# Altium schematic sheet [07]-MCU.SchDoc
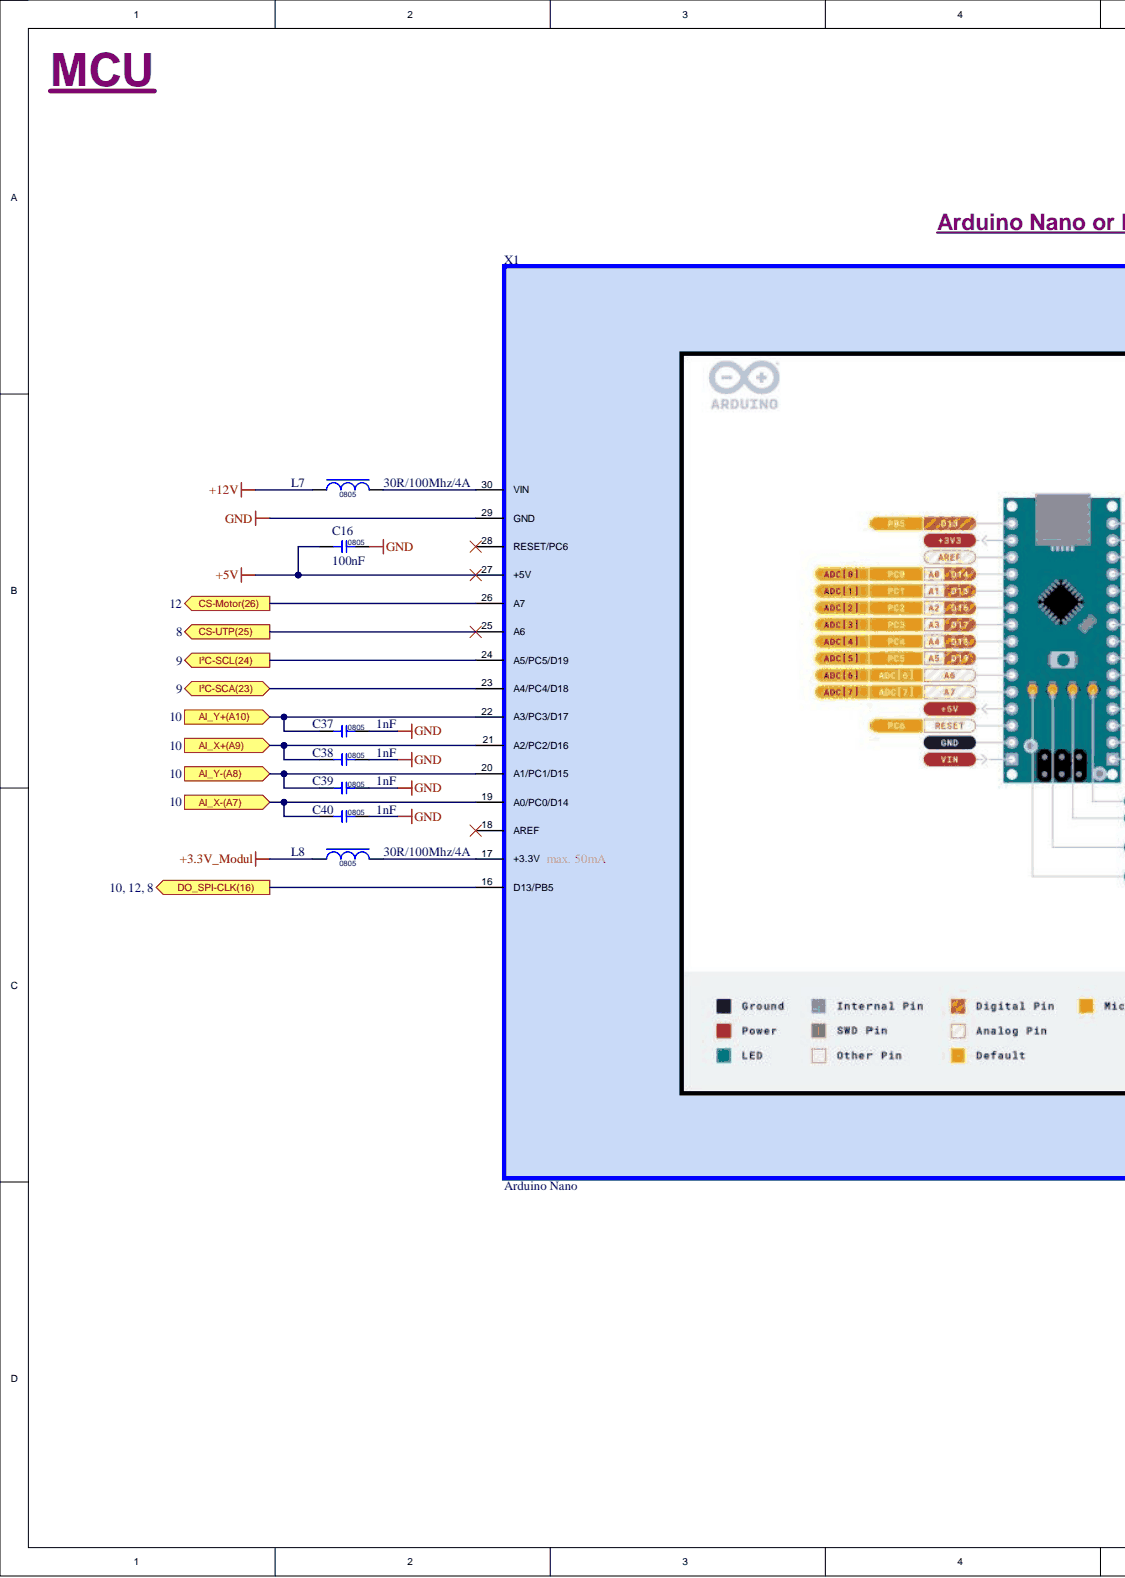
[diagram p0: [07]-MCU.SchDoc, left]
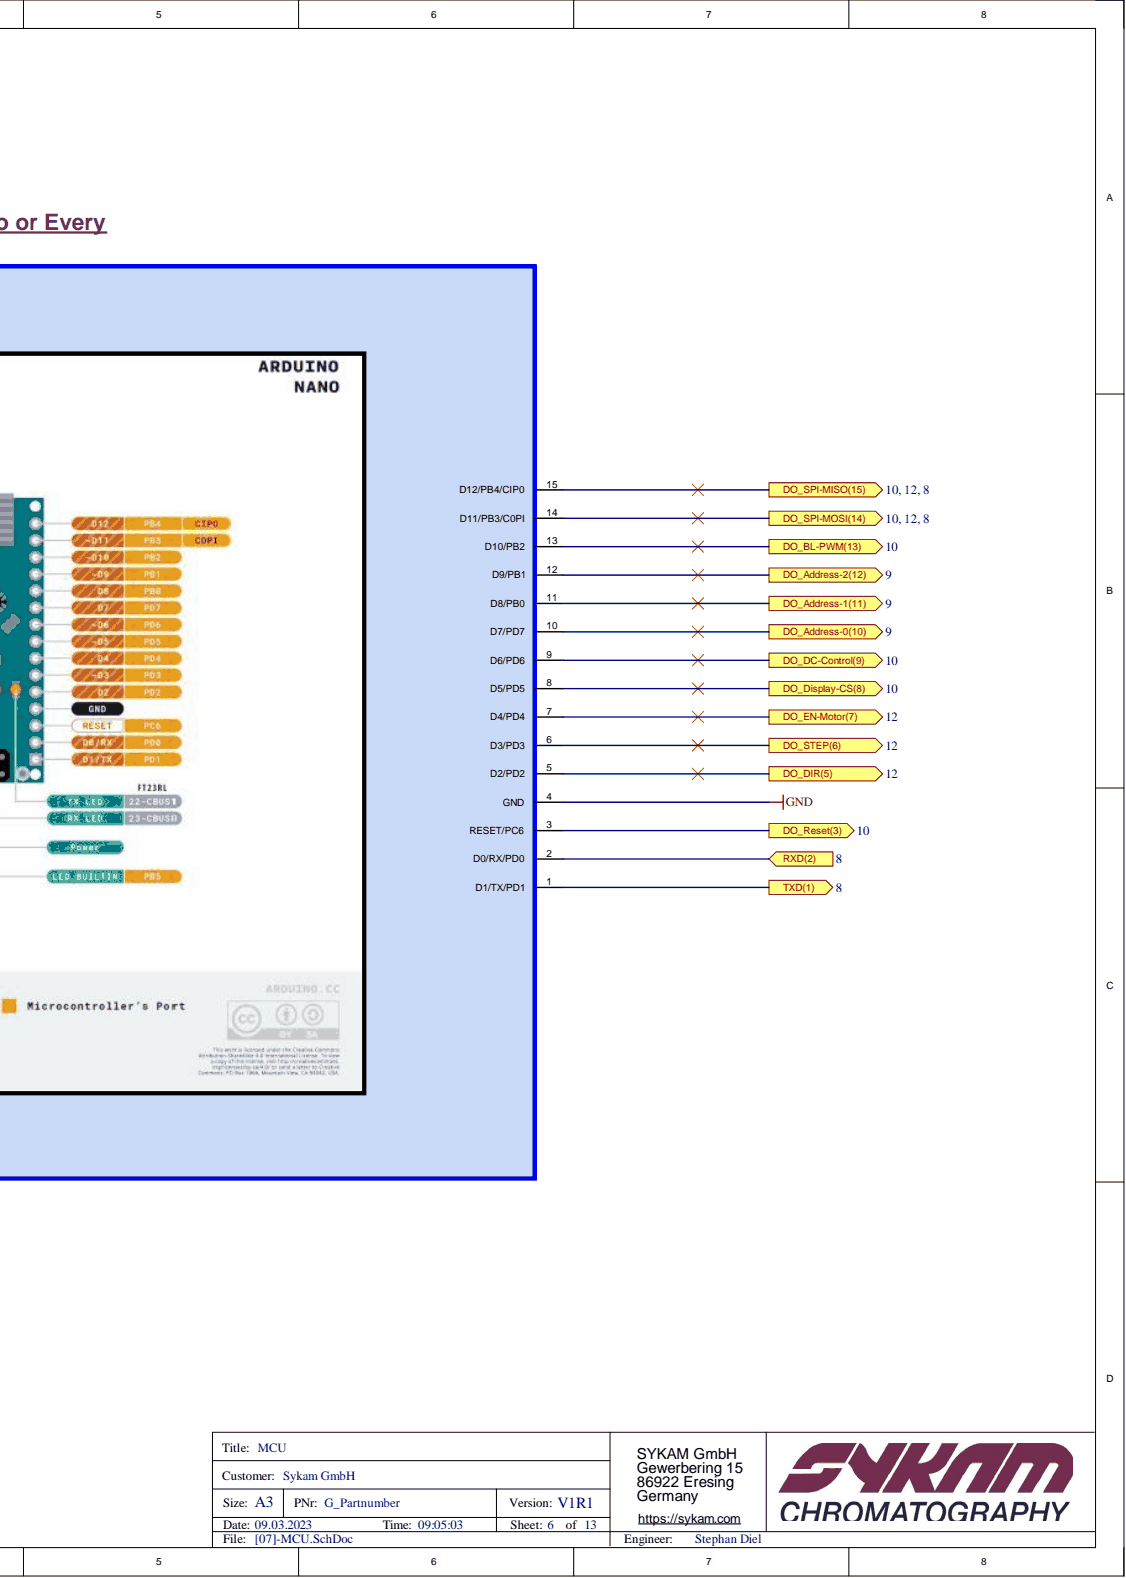
[diagram p1: [07]-MCU.SchDoc, right]

## Parts
C16  100nF  Case Code (Imperial): 0805  [C0805]
C37  1nF  Case Code (Imperial): 0805  [C0805]
C38  1nF  Case Code (Imperial): 0805  [C0805]
C39  1nF  Case Code (Imperial): 0805  [C0805]
C40  1nF  Case Code (Imperial): 0805  [C0805]
L7  30R/100Mhz/4A  Case Code (Imperial): 0805  [L0805]
L8  30R/100Mhz/4A  Case Code (Imperial): 0805  [L0805]
X1  Arduino Nano  Manufacturer: Arduino  [Arduino-Nano-Connectors]

## Ports
AI_X+(A9), AI_X-(A7), AI_Y+(A10), AI_Y-(A8), CS-Motor(26), CS-UTP(25), DO_Address-0(10), DO_Address-1(11), DO_Address-2(12), DO_BL-PWM(13), DO_DC-Control(9), DO_DIR(5), DO_Display-CS(8), DO_EN-Motor(7), DO_Reset(3), DO_SPI-CLK(16), DO_SPI-MISO(15), DO_SPI-MOSI(14), DO_STEP(6), I²C-SCA(23), I²C-SCL(24), RXD(2), TXD(1)

## Nets
+3.3V_Modul: L8.1
+5V: C16.1 X1.27(+5V)
+12V: L7.1
GND: C16.2 C37.2 C38.2 C39.2 C40.2 X1.4(GND) X1.29(GND)
AI_X+(A9): C38.1 X1.21(A2/PC2/D16) ; port AI_X+(A9)
AI_X-(A7): C40.1 X1.19(A0/PC0/D14) ; port AI_X-(A7)
AI_Y+(A10): C37.1 X1.22(A3/PC3/D17) ; port AI_Y+(A10)
AI_Y-(A8): C39.1 X1.20(A1/PC1/D15) ; port AI_Y-(A8)
CS-Motor(26): X1.26(A7) ; port CS-Motor(26)
CS-UTP(25): X1.25(A6) ; port CS-UTP(25)
DO_Address-0(10): X1.10(D7/PD7) ; port DO_Address-0(10)
DO_Address-1(11): X1.11(D8/PB0) ; port DO_Address-1(11)
DO_Address-2(12): X1.12(D9/PB1) ; port DO_Address-2(12)
DO_BL-PWM(13): X1.13(D10/PB2) ; port DO_BL-PWM(13)
DO_DC-Control(9): X1.9(D6/PD6) ; port DO_DC-Control(9)
DO_DIR(5): X1.5(D2/PD2) ; port DO_DIR(5)
DO_Display-CS(8): X1.8(D5/PD5) ; port DO_Display-CS(8)
DO_EN-Motor(7): X1.7(D4/PD4) ; port DO_EN-Motor(7)
DO_Reset(3): X1.3(RESET/PC6) ; port DO_Reset(3)
DO_SPI-CLK(16): X1.16(D13/PB5) ; port DO_SPI-CLK(16)
DO_SPI-MISO(15): X1.15(D12/PB4/CIP0) ; port DO_SPI-MISO(15)
DO_SPI-MOSI(14): X1.14(D11/PB3/C0PI) ; port DO_SPI-MOSI(14)
DO_STEP(6): X1.6(D3/PD3) ; port DO_STEP(6)
I²C-SCA(23): X1.23(A4/PC4/D18) ; port I²C-SCA(23)
I²C-SCL(24): X1.24(A5/PC5/D19) ; port I²C-SCL(24)
RXD(2): X1.2(D0/RX/PD0) ; port RXD(2)
TXD(1): X1.1(D1/TX/PD1) ; port TXD(1)
NetL7_2: L7.2 X1.30(VIN)
NetL8_2: L8.2 X1.17(+3.3V)

Not connected: X1 18(AREF) 28(RESET/PC6)

## Library data of the parts
C16 = C_100nF_CC0805KRX7R9BB104: CAP CER 0.1UF 50V X7R 0805; Manufacturer: Yageo; Insulation Resistance: 100GR; Material: Ceramic; PCBChecked: initials after Checked; Tolerance: 10%; Capacitance: 100nF; Case Code (Metric): 2012; Case/Package: 0805; Category: Ceramic Capacitors; Component Kind: Standard; ComponentLink1Description: https://datasheet.ciiva.com/11786/0900766b80d84f1d-11786009.pdf?src-supplier=RSComponents; ComponentLink1URL: https://datasheet.ciiva.com/11786/0900766b80d84f1d-11786009.pdf?src-supplier=RSComponents; ComponentLink2Description: https://datasheet.ciiva.com/11464/upy-gphc-x7r-6-3v-to-50v-8-11464757.pdf?src-supplier=Avnet; ComponentLink2URL: https://datasheet.ciiva.com/11464/upy-gphc-x7r-6-3v-to-50v-8-11464757.pdf?src-supplier=Avnet; ComponentLink3Description: https://datasheet.ciiva.com/28450/1489800-28450805.pdf?src-supplier=Element14; ComponentLink3URL: https://datasheet.ciiva.com/28450/1489800-28450805.pdf?src-supplier=Element14; ComponentLink4Description: https://datasheet.ciiva.com/155/mlccorderingcode-155929.pdf?src-supplier=Digi-Key; ComponentLink4URL: https://datasheet.ciiva.com/155/mlccorderingcode-155929.pdf?src-supplier=Digi-Key; ComponentLink5Description: https://datasheet.ciiva.com/155/mlccorderingcode-155930.pdf?src-supplier=Digi-Key; ComponentLink5URL: https://datasheet.ciiva.com/155/mlccorderingcode-155930.pdf?src-supplier=Digi-Key; ComponentLink6Description: https://datasheet.ciiva.com/155/mlccorderingcode-155931.pdf?src-supplier=Digi-Key; ComponentLink6URL: https://datasheet.ciiva.com/155/mlccorderingcode-155931.pdf?src-supplier=Digi-Key; ComponentLink7Description: https://datasheet.ciiva.com/46393/1734573-46393307.pdf?src-supplier=Element14; ComponentLink7URL: https://datasheet.ciiva.com/46393/1734573-46393307.pdf?src-supplier=Element14; ComponentLink8Description: https://datasheet.ciiva.com/16625/upy-gphc-x7r-6-3v-to-50v-8-16625549.pdf?src-supplier=Avnet+Europe; ComponentLink8URL: https://datasheet.ciiva.com/16625/upy-gphc-x7r-6-3v-to-50v-8-16625549.pdf?src-supplier=Avnet+Europe; ComponentLink9Description: https://datasheet.ciiva.com/29242/445210-da-01-en-kerko-chip-0805-x7r-0-1uf-10proz-50vg-29242074.pdf?src-supplier=Conrad; ComponentLink9URL: https://datasheet.ciiva.com/29242/445210-da-01-en-kerko-chip-0805-x7r-0-1uf-10proz-50vg-29242074.pdf?src-supplier=Conrad; Depth: 1.25mm; Dielectric: X7R; Halogen Free: Halogen Free; Height: 0.85mm; IPC7352C: done; Lead Free: Lead Free; Length: 2mm; Manufacturer Part Number: CC0805KRX7R9BB104; Max Operating Temperature: 125°C; Min Operating Temperature: -55°C; Mount: Surface Mount; Number of Pins: 2; Package Quantity: 1; Packaging: Tape and Reel; Radiation Hardening: No; REACH SVHC: No SVHC; RoHS: Yes; Stock 1: 7118979; Supplier 1: Digi-Key; Supplier Part Number 1: 311-1140-6-ND; Termination: SMD/SMT; Thickness: 0.033inch; Voltage: 50V; Voltage Rating: 50V; Width: 0.049inch; Library Name: ToradexMainBoard-V0I1.SCHLIB; SCHChecked: Initials after checked
C37-C40 = C_1nF_C0805C102K5RAC7411: Multilayer Ceramic Capacitors MLCC - SMD/SMT 50volts 1000pF X7R 10%; Manufacturer: KEMET; Voltage Rating: 50V; Capacitance: 1nF; Case Code (Metric): 2012; Case/Package: 0805; Category: Ceramic Capacitors; Component Kind: Standard; ComponentLink1Description: http://components.arrow.com/part/dataview/0323_00324664?src-supplier=Arrow+Europe; ComponentLink1URL: http://components.arrow.com/part/dataview/0323_00324664?src-supplier=Arrow+Europe; ComponentLink2Description: http://www.mouser.com/ds/2/212/KEM_C1002_X7R_SMD-1102033.pdf?src-supplier=Mouser; ComponentLink2URL: http://www.mouser.com/ds/2/212/KEM_C1002_X7R_SMD-1102033.pdf?src-supplier=Mouser; ComponentLink3Description: https://api.kemet.com/component-edge/download/datasheet/C0805C102K5RAC7411.pdf?src-supplier=Digi-Key; ComponentLink3URL: https://api.kemet.com/component-edge/download/datasheet/C0805C102K5RAC7411.pdf?src-supplier=Digi-Key; Dielectric: X7R; Dielectric Material: Ceramic; Height: 0.78mm; Length: 0.079inch; Manufacturer Part Number: C0805C102K5RAC7411; Max Operating Temperature: 125°C; Min Operating Temperature: -55°C; Mount: Surface Mount; Package Quantity: 15000; Packaging: Tape and Reel; Pricing 1: 15000=0,01862, 30000=0,01764 (USD); RoHS: Yes; Stock 1: 30000; Supplier 1: Digi-Key; Supplier Part Number 1: 311-1127-1-ND; Termination: SMD/SMT; Thickness: 0.035inch; Tolerance: 10%; Voltage Rating (DC): 50V; Width: 0.049inch; Library Name: ToradexMainBoard-V0I1.SCHLIB
L7 L8 = L_BLM21PG300SN1D: Ferrite Beads Multi-Layer 30Ohm 100MHz 4A 14mOhm DCR 0805 T/R; Manufacturer: Murata; DC Current: 3A; DC Resistance (DCR): 14mR; Impedance: 30R; Material: Steel,Ferrite; Number of Circuits: 1; PCBChecked: initials after Checked; Resistance: 15mR; Shielding: Unshielded; Tolerance: 25%; Case Code (Metric): 2012; Case/Package: 0805; Category: Ferrite Beads and Chips; Component Kind: Standard; ComponentLink1Description: https://datasheet.ciiva.com/11795/0900766b80021a8e-11795584.pdf?src-supplier=RSComponents; ComponentLink1URL: https://datasheet.ciiva.com/11795/0900766b80021a8e-11795584.pdf?src-supplier=RSComponents; ComponentLink2Description: https://datasheet.ciiva.com/19460/1845339-19460237.pdf?src-supplier=Jameco; ComponentLink2URL: https://datasheet.ciiva.com/19460/1845339-19460237.pdf?src-supplier=Jameco; ComponentLink3Description: https://datasheet.ciiva.com/25903/l0110s0100blm21p-25903510.pdf?src-supplier=Avnet+Europe; ComponentLink3URL: https://datasheet.ciiva.com/25903/l0110s0100blm21p-25903510.pdf?src-supplier=Avnet+Europe; ComponentLink4Description: https://datasheet.ciiva.com/3081/l0110s0100blm21p-3081657.pdf?src-supplier=Avnet; ComponentLink4URL: https://datasheet.ciiva.com/3081/l0110s0100blm21p-3081657.pdf?src-supplier=Avnet; ComponentLink5Description: https://datasheet.ciiva.com/16199/l0110s0100blm21p-16199625.pdf?src-supplier=Future+Electronics; ComponentLink5URL: https://datasheet.ciiva.com/16199/l0110s0100blm21p-16199625.pdf?src-supplier=Future+Electronics; ComponentLink6Description: https://datasheet.ciiva.com/3081/l0110s0100blm21p-3081658.pdf?src-supplier=Avnet; ComponentLink6URL: https://datasheet.ciiva.com/3081/l0110s0100blm21p-3081658.pdf?src-supplier=Avnet; ComponentLink7Description: https://datasheet.ciiva.com/6212/l0110s0100blm21p-6212358.pdf?src-supplier=Digi-Key; ComponentLink7URL: https://datasheet.ciiva.com/6212/l0110s0100blm21p-6212358.pdf?src-supplier=Digi-Key; ComponentLink8Description: https://datasheet.ciiva.com/6212/l0110s0100blm21p-6212360.pdf?src-supplier=Digi-Key; ComponentLink8URL: https://datasheet.ciiva.com/6212/l0110s0100blm21p-6212360.pdf?src-supplier=Digi-Key; ComponentLink9Description: https://datasheet.ciiva.com/8021/1756152-8021599.pdf?src-supplier=Element14; ComponentLink9URL: https://datasheet.ciiva.com/8021/1756152-8021599.pdf?src-supplier=Element14; ComponentLink10Description: https://datasheet.ciiva.com/41449/001172365-da-01-en-drossel-0805-30r0-2-0x1-25mm-4000st-41449606.pdf?src-supplier=Conrad; ComponentLink10URL: https://datasheet.ciiva.com/41449/001172365-da-01-en-drossel-0805-30r0-2-0x1-25mm-4000st-41449606.pdf?src-supplier=Conrad; Core Material: Ferrite; Current Rating: 4A; Depth: 1.25mm; Frequency: 100MHz; Height: 0.85mm; IPC7352C: done; Lead Free: Lead Free; Length: 2mm; Manufacturer Part Number: BLM21PG300SN1D; Max Current Rating: 4A; Max DC Current: 3A; Max Operating Temperature: 125°C; Military Standard: Not; Min Operating Temperature: -55°C; Number of Lines: 1; Number of Pins: 2; Package Quantity: 1; Packaging: Tape and Reel; Radiation Hardening: No; REACH SVHC: No SVHC; RoHS: Yes; Stock 1: 88452; Supplier 1: Digi-Key; Supplier Part Number 1: 490-1052-1-ND; Termination: SMD/SMT; Test Frequency: 100MHz; Width: 1.25mm; Library Name: ToradexMainBoard-V0I1.SCHLIB; SCHChecked: Initials after checked
X1 = CON_Modul_ArduinoNano3: ATmega328 Microcontroller Development Board 16MHz CPU 2KB RAM 1KB/32KB EEPROM/Flash; Manufacturer Part Number: A000005; Core Architecture: AVR; Data Bus Width: 8b; Evaluation Kit: Yes; Interface: I2C,SPI,USB; Number of Bits: 8; Operating Supply Voltage: 12V; Category: Embedded Processors and Controllers; Component Kind: Standard; ComponentLink1Description: https://datasheet.ciiva.com/2398/arduinonanomanual23-2398236.pdf?src-supplier=Digi-Key; ComponentLink1URL: https://datasheet.ciiva.com/2398/arduinonanomanual23-2398236.pdf?src-supplier=Digi-Key; ComponentLink2Description: https://datasheet.ciiva.com/19455/2121148-19455443.pdf?src-supplier=Jameco; ComponentLink2URL: https://datasheet.ciiva.com/19455/2121148-19455443.pdf?src-supplier=Jameco; ComponentLink3Description: https://datasheet.ciiva.com/1336/1682238-1336473.pdf?src-supplier=Element14; ComponentLink3URL: https://datasheet.ciiva.com/1336/1682238-1336473.pdf?src-supplier=Element14; ComponentLink4Description: https://datasheet.ciiva.com/1414/810078-1414124.pdf?src-supplier=Newark; ComponentLink4URL: https://datasheet.ciiva.com/1414/810078-1414124.pdf?src-supplier=Newark; ComponentLink5Description: https://datasheet.ciiva.com/4926/arduino-arduinoboardnano-188748-4926316.pdf?src-supplier=Mouser; ComponentLink5URL: https://datasheet.ciiva.com/4926/arduino-arduinoboardnano-188748-4926316.pdf?src-supplier=Mouser; Height: 0.25inch; Length: 7inch; Package Quantity: 50; Packaging: Bulk; Pricing 1: 1=21,19000 (EUR); Reach SVHC Compliant: Yes; RoHS: Yes; Series: AVR® ATmega; Stock 1: 251; Supplier 1: Farnell; Supplier Part Number 1: 1848691; Weight: 0.358383oz; Width: 5inch; Library Name: ToradexMainBoard-V0I1.SCHLIB
